# Altium schematic sheet main.SchDoc
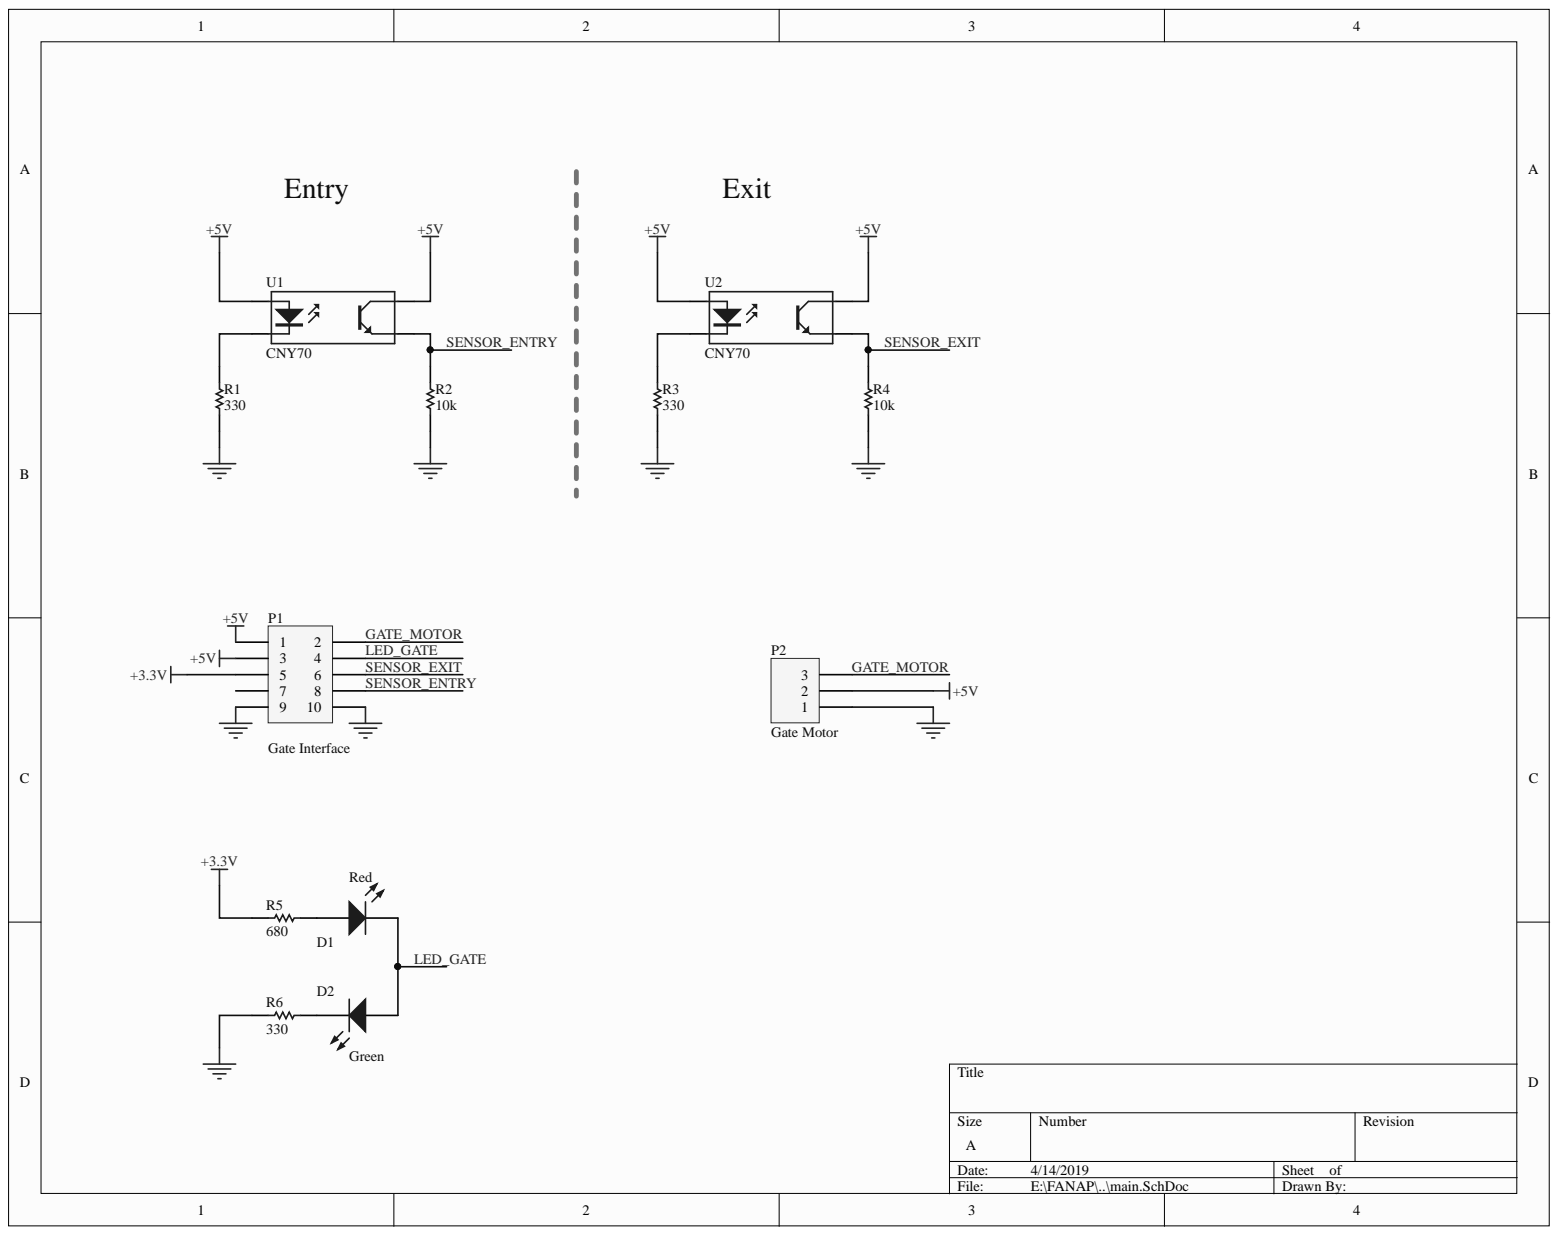
[diagram p0: main.SchDoc]

## Parts
D1  Red  [LED3mm]
D2  Green  [LED3mm]
P1  info: Gate Interface  [HDR2X5H]  (hidden comment: MHDR2X5)
P2  Gate Motor  [HDR1X3H]
R1  value 330  [AXIAL-0.4]  (hidden comment: Axial Res)
R2  value 10k  [AXIAL-0.4]  (hidden comment: Axial Res 10K)
R3  value 330  [AXIAL-0.4]  (hidden comment: Axial Res)
R4  value 10k  [AXIAL-0.4]  (hidden comment: Axial Res 10K)
R5  value 680  [AXIAL-0.4]  (hidden comment: Axial Res)
R6  value 330  [AXIAL-0.4]  (hidden comment: Axial Res)
U1  CNY70  [CNY70]
U2  CNY70  [CNY70]

## Nets
+3.3V: P1.5 R5.2
+5V: P1.1 P1.3 P2.2 U1.1 U1.4 U2.1 U2.4
GND: P1.9 P1.10 P2.1 R1.1 R2.1 R3.1 R4.1 R6.2
GATE_MOTOR: P1.2 P2.3
LED_GATE: D1.2 D2.1 P1.4
SENSOR_ENTRY: P1.8 R2.2 U1.3
SENSOR_EXIT: P1.6 R4.2 U2.3
NetD1_1: D1.1 R5.1
NetD2_2: D2.2 R6.1
NetR1_2: R1.2 U1.2
NetR3_2: R3.2 U2.2

Not connected: P1 7

## Library data of the parts
D1 = LED3: Typical 3mm LED (RED); Component Kind: Standard; DatasheetVersion: 16-Apr-2002; LatestRevisionDate: 17-Dec-2003; LatestRevisionNote: PCB Footprint replaced.; Library Name: Miscellaneous Devices.IntLib; PackageDescription: SM LED; 2 C-Bend Leads; Body 3.5 x 2.8 x 1.9 mm, inc leads (LxWxH typ); PackageReference: 3.5X2.8X1.9; PackageVersion: 16-Apr-2002; Published: 8-Jun-2000; Signal Integrity: LED; Simulation: LED3; Publisher: Altium Limited
D2 = LED3: Typical 3mm LED (Green); Component Kind: Standard; DatasheetVersion: 16-Apr-2002; LatestRevisionDate: 17-Dec-2003; LatestRevisionNote: PCB Footprint replaced.; Library Name: Miscellaneous Devices.IntLib; PackageDescription: SM LED; 2 C-Bend Leads; Body 3.5 x 2.8 x 1.9 mm, inc leads (LxWxH typ); PackageReference: 3.5X2.8X1.9; PackageVersion: 16-Apr-2002; Published: 8-Jun-2000; Signal Integrity: LED; Simulation: LED3; Publisher: Altium Limited
P1 = MHDR2X5: Header, 5-Pin, Dual row; Component Kind: Standard; LatestRevisionDate: 17-Jul-2002; LatestRevisionNote: Re-released for DXP Platform.; Library Name: Miscellaneous Connectors.IntLib; Signal Integrity: Connector; Publisher: Altium Limited
P2 = Header 3H: Header, 3-Pin, Right Angle; Component Kind: Standard; LatestRevisionDate: 17-Jul-2002; LatestRevisionNote: Re-released for DXP Platform.; Library Name: Miscellaneous Connectors.IntLib; Signal Integrity: Connector; Publisher: Altium Limited
R1 R3 R6 = Res1: Resistor 330 ohm; Component Kind: Standard; LatestRevisionDate: 17-Jul-2002; LatestRevisionNote: Re-released for DXP Platform.; Library Name: Miscellaneous Devices.IntLib; PackageDescription: Axial Device, Thru-Hole; 2 Leads; 0.3 in Pin Spacing; PackageReference: AXIAL-0.3; Published: 8-Jun-2000; Signal Integrity: Res; Simulation: RESISTOR; Publisher: Altium Limited
R2 R4 = Res1: Resistor 10K ohm; Component Kind: Standard; LatestRevisionDate: 17-Jul-2002; LatestRevisionNote: Re-released for DXP Platform.; Library Name: Miscellaneous Devices.IntLib; PackageDescription: Axial Device, Thru-Hole; 2 Leads; 0.3 in Pin Spacing; PackageReference: AXIAL-0.3; Published: 8-Jun-2000; Signal Integrity: Res; Simulation: RESISTOR; Publisher: Altium Limited
R5 = Res1: Resistor 680 ohm; Component Kind: Standard; LatestRevisionDate: 17-Jul-2002; LatestRevisionNote: Re-released for DXP Platform.; Library Name: Miscellaneous Devices.IntLib; PackageDescription: Axial Device, Thru-Hole; 2 Leads; 0.3 in Pin Spacing; PackageReference: AXIAL-0.3; Published: 8-Jun-2000; Signal Integrity: Res; Simulation: RESISTOR; Publisher: Altium Limited
U1 U2 = Optoisolator1: Reflective IR sensor; Component Kind: Standard; DatasheetVersion: Nov-2003; LatestRevisionDate: 04-Mar-2005; LatestRevisionNote: Sch pins 3 & 4 Swapped.; Library Name: Miscellaneous Devices.IntLib; PackageReference: DIP-4; Published: 24-Mar-1999; Publisher: Altium Limited
